# Altium schematic sheet Schematic2_.SchDoc(Schematic2_)
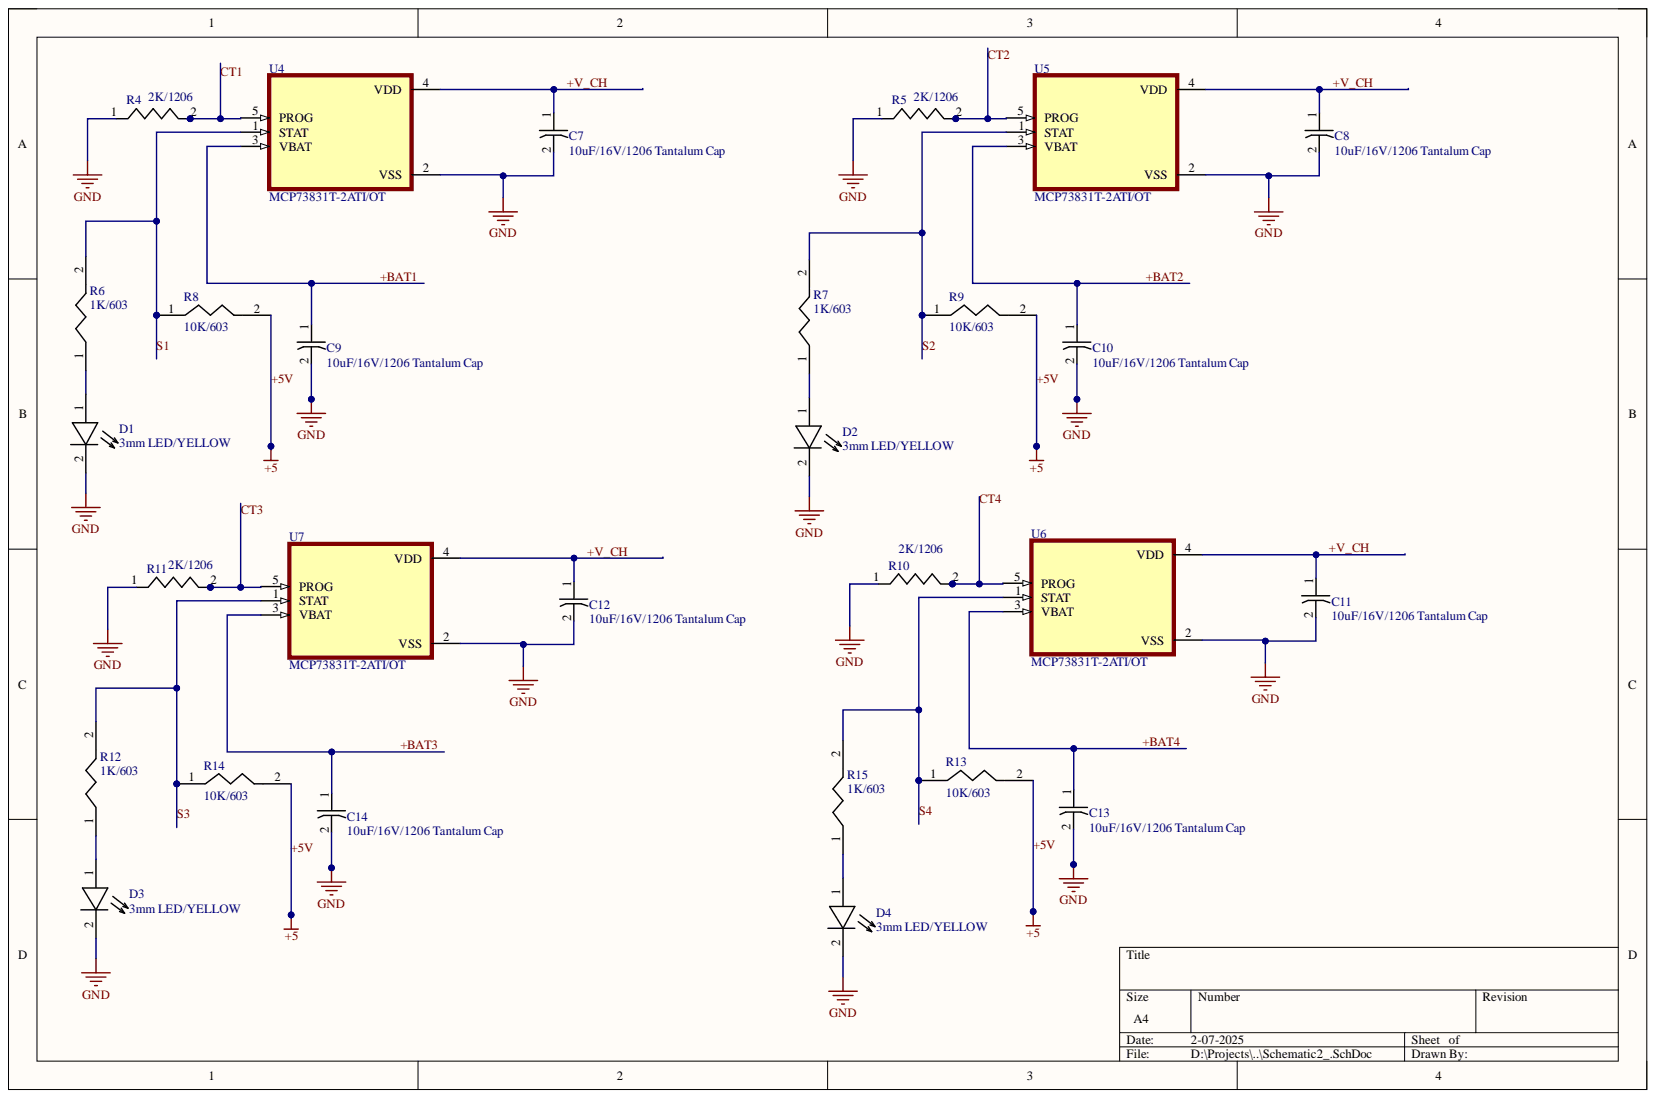
[diagram p0: Schematic2_.SchDoc]

## Parts
C7  10uF/16V/1206 Tantalum Cap  [1206 POL CAP]
C8  10uF/16V/1206 Tantalum Cap  [1206 POL CAP]
C9  10uF/16V/1206 Tantalum Cap  [1206 POL CAP]
C10  10uF/16V/1206 Tantalum Cap  [1206 POL CAP]
C11  10uF/16V/1206 Tantalum Cap  [1206 POL CAP]
C12  10uF/16V/1206 Tantalum Cap  [1206 POL CAP]
C13  10uF/16V/1206 Tantalum Cap  [1206 POL CAP]
C14  10uF/16V/1206 Tantalum Cap  [1206 POL CAP]
D1  3mm LED/YELLOW  [3mm Led]
D2  3mm LED/YELLOW  [3mm Led]
D3  3mm LED/YELLOW  [3mm Led]
D4  3mm LED/YELLOW  [3mm Led]
R4  2K/1206  [1206]
R5  2K/1206  [1206]
R6  1K/603  [603]
R7  1K/603  [603]
R8  10K/603  [603]
R9  10K/603  [603]
R10  2K/1206  [1206]
R11  2K/1206  [1206]
R12  1K/603  [603]
R13  10K/603  [603]
R14  10K/603  [603]
R15  1K/603  [603]
U4  MCP73831T-2ATI/OT  [SOT95P280X145-5N]
U5  MCP73831T-2ATI/OT  [SOT95P280X145-5N]
U6  MCP73831T-2ATI/OT  [SOT95P280X145-5N]
U7  MCP73831T-2ATI/OT  [SOT95P280X145-5N]

## Nets
GND: C7.2 C8.2 C9.2 C10.2 C11.2 C12.2 C13.2 C14.2 D1.2 D2.2 D3.2 D4.2 R4.1 R5.1 R10.1 R11.1 U4.2(VSS) U5.2(VSS) U6.2(VSS) U7.2(VSS)
+5V: R8.2 R9.2 R13.2 R14.2
+BAT1: C9.1 U4.3(VBAT)
+BAT2: C10.1 U5.3(VBAT)
+BAT3: C14.1 U7.3(VBAT)
+BAT4: C13.1 U6.3(VBAT)
+V_CH: C7.1 C8.1 C11.1 C12.1 U4.4(VDD) U5.4(VDD) U6.4(VDD) U7.4(VDD)
CT1: R4.2 U4.5(PROG)
CT2: R5.2 U5.5(PROG)
CT3: R11.2 U7.5(PROG)
CT4: R10.2 U6.5(PROG)
S1: R6.2 R8.1 U4.1(STAT)
S2: R7.2 R9.1 U5.1(STAT)
S3: R12.2 R14.1 U7.1(STAT)
S4: R13.1 R15.2 U6.1(STAT)
NetD1_1: D1.1 R6.1
NetD2_1: D2.1 R7.1
NetD3_1: D3.1 R12.1
NetD4_1: D4.1 R15.1

## Library data of the parts
C7-C14 = 1206 POL CAP: Component Kind: Standard; Library Name: Custom1.IntLib
D1-D4 = 3mm LED: Component Kind: Standard; Library Name: Custom1.IntLib
R4 R5 R10 R11 = 1206 Resistor: Component Kind: Standard; Library Name: Custom1.IntLib
R6-R9 R12-R15 = 603 Resistor: Component Kind: Standard; Library Name: Custom1.IntLib
U4-U7 = MCP73831T-2ATI/OT: Charger IC Lithium Ion/Polymer SOT-23-5; MF: Microchip; Arrow_Americas-Purchase-URL: https://snapeda.com/shop?store=Arrow+Americas&id=51423; Availability: Unavailable; Component Kind: Standard; DigiKey-Purchase-URL: https://snapeda.com/shop?store=DigiKey&id=51423; Library Name: MCP73831T-2ATI_OT.IntLib; Mouser-Purchase-URL: https://snapeda.com/shop?store=Mouser&id=51423; MP: MCP73831T-2ATI/OT; Package: SOT-23 Microchip; Price: None; SnapEDA_Link: https://www.snapeda.com/parts/MCP73831T-2ATI/OT/Microchip/view-part/51423/?ref=snap
